# Altium schematic sheet LED.SchDoc
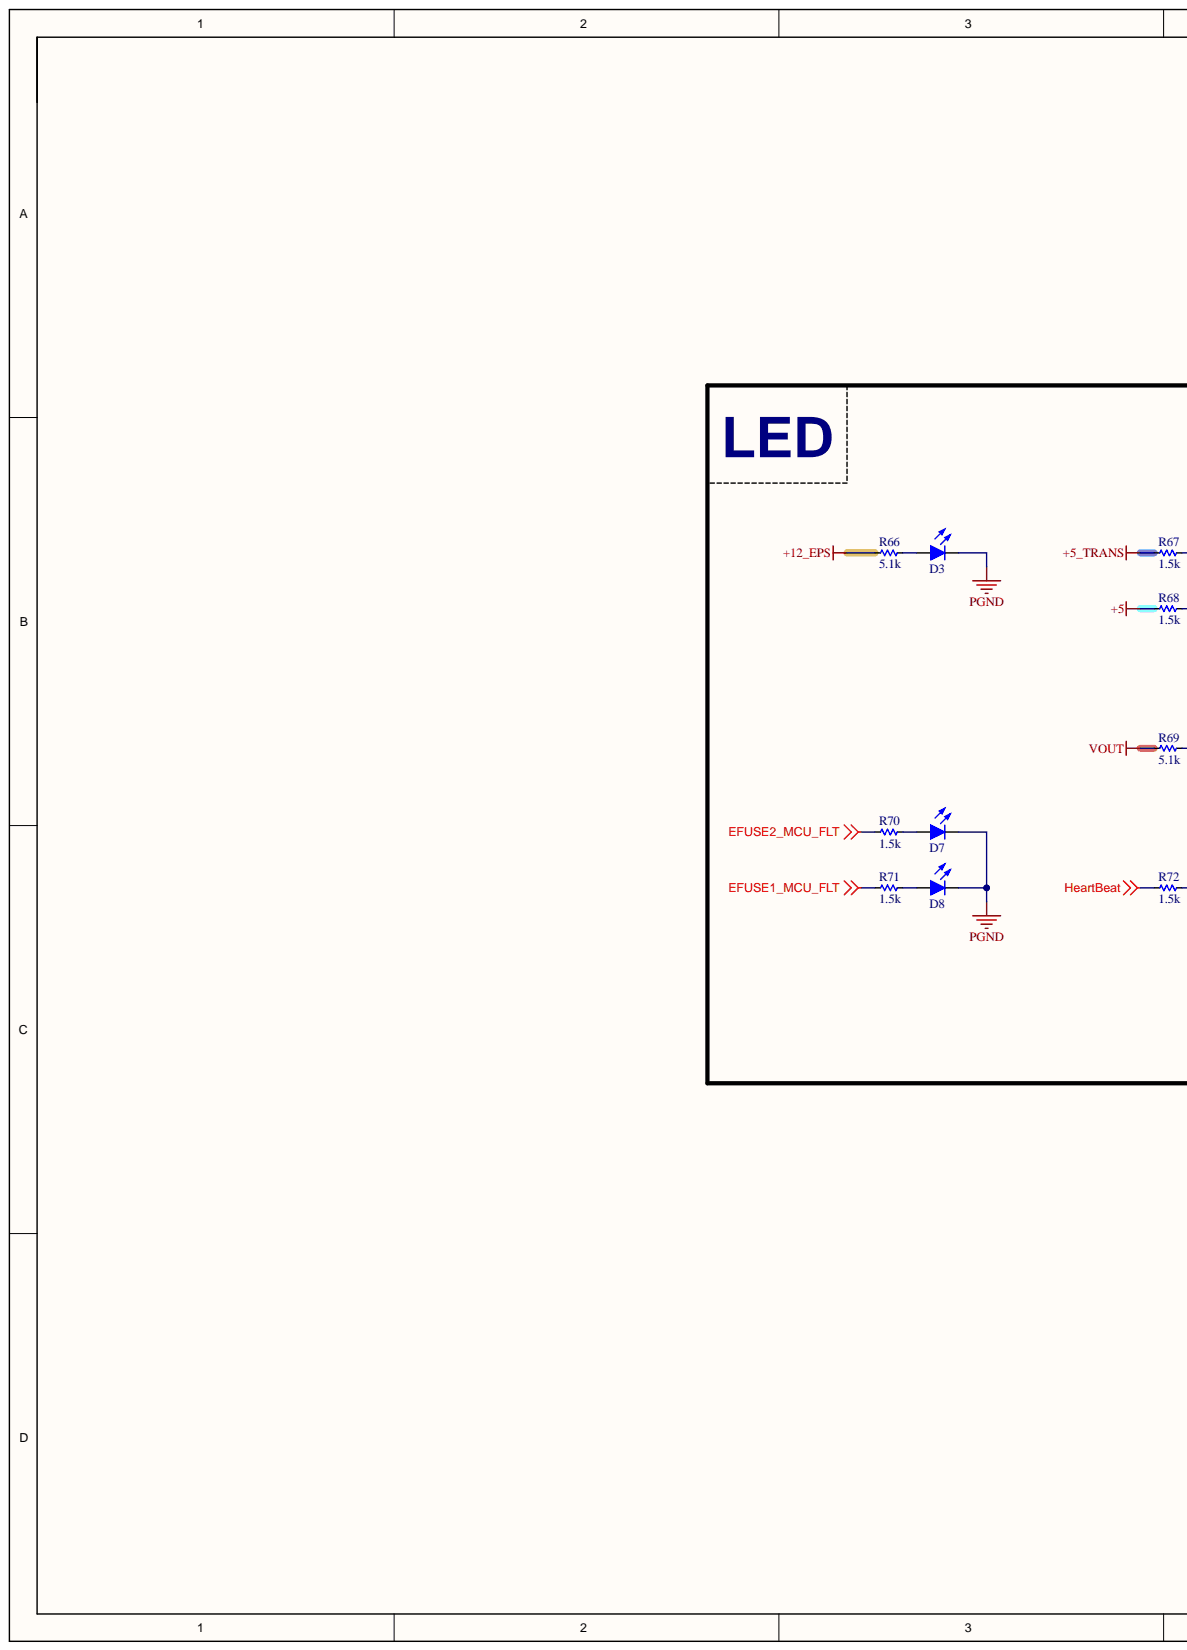
[diagram p0: LED.SchDoc, left]
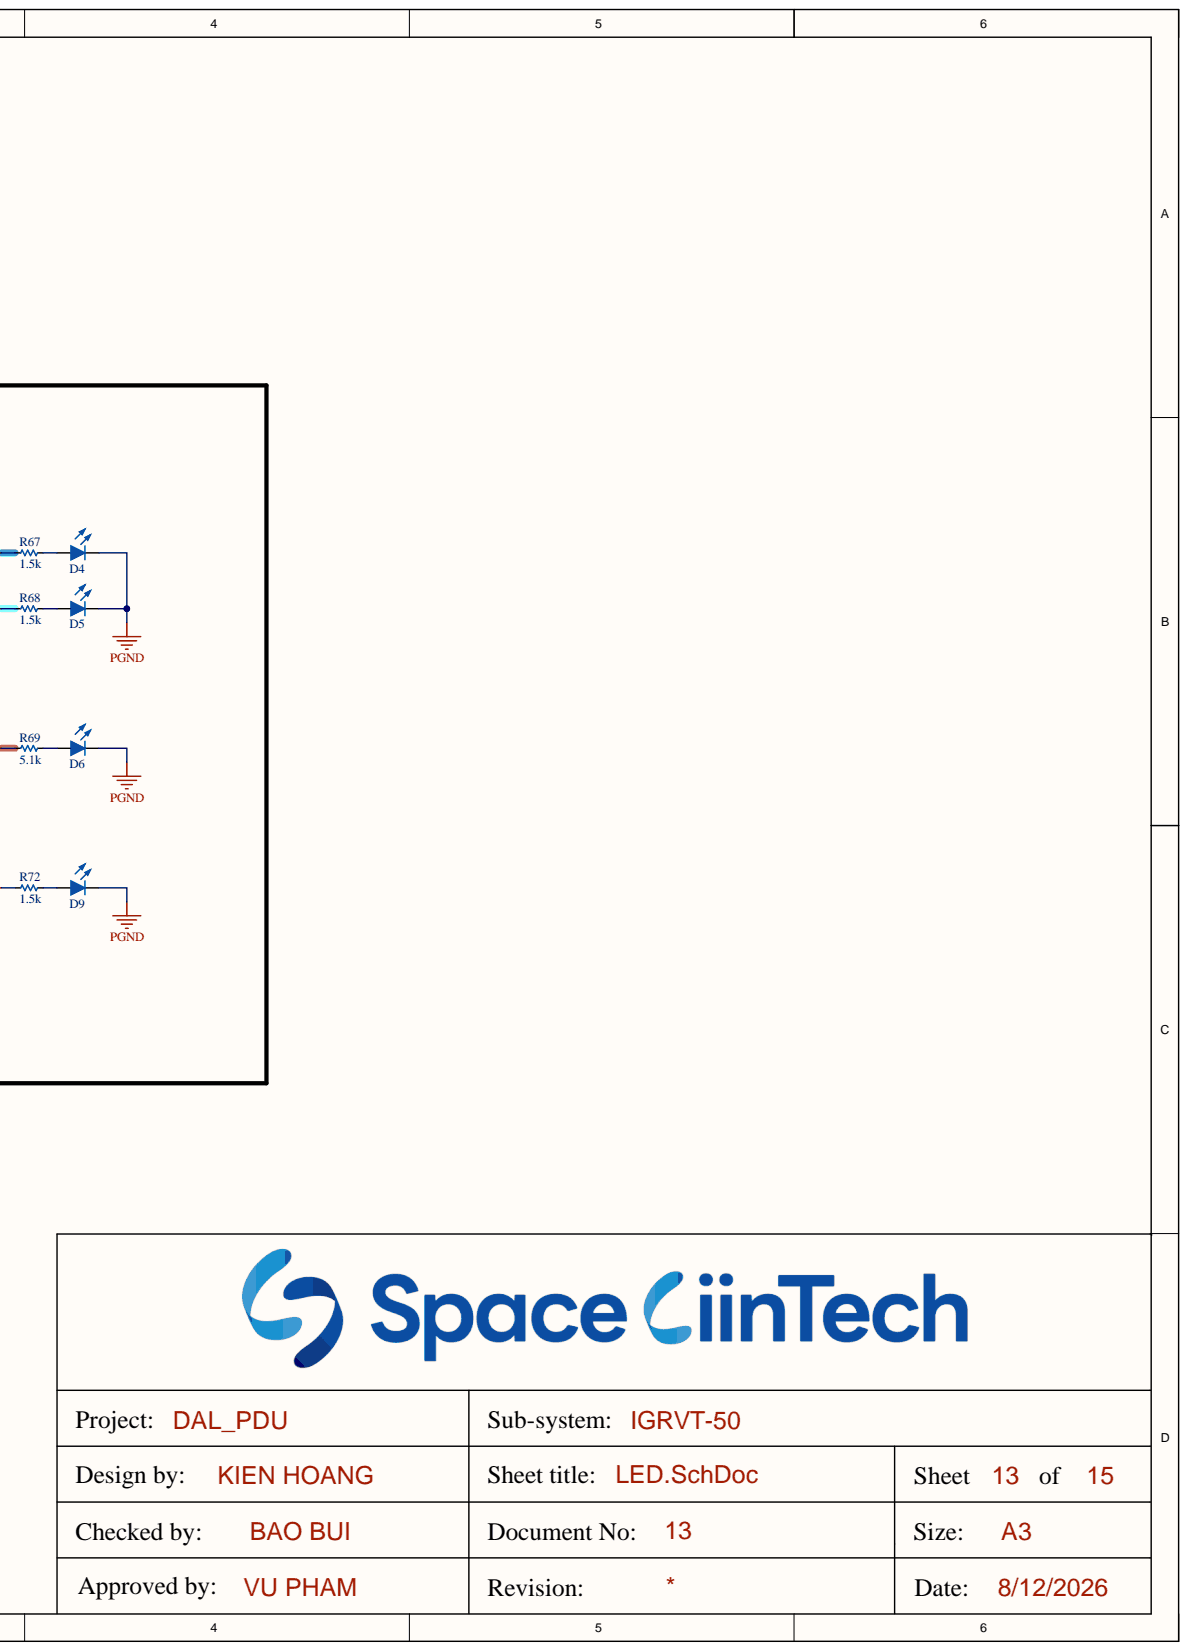
[diagram p1: LED.SchDoc, right]

## Parts
D3  Led  [Led 0603]  (value not drawn)
D4  Led  [Led 0603]  (value not drawn)
D5  Led  [Led 0603]  (value not drawn)
D6  Led  [Led 0603]  (value not drawn)
D7  Led  [Led 0603]  (value not drawn)
D8  Led  [Led 0603]  (value not drawn)
D9  Led  [Led 0603]  (value not drawn)
R66  5.1k  [RES_C_1608X60]
R67  1.5k  [RES_C_1608X60]
R68  1.5k  [RES_C_1608X60]
R69  5.1k  [RES_C_1608X60]
R70  1.5k  [RES_C_1608X60]
R71  1.5k  [RES_C_1608X60]
R72  1.5k  [RES_C_1608X60]

## Nets
+5: R68.2
+5_TRANS: R67.2
+12_EPS: R66.2
EFUSE1_MCU_FLT: R71.2
EFUSE2_MCU_FLT: R70.2
HeartBeat: R72.2
PGND: D3.2 D4.2 D5.2 D6.2 D7.2 D8.2 D9.2
VOUT: R69.2
NetD3_1: D3.1 R66.1
NetD4_1: D4.1 R67.1
NetD5_1: D5.1 R68.1
NetD6_1: D6.1 R69.1
NetD7_1: D7.1 R70.1
NetD8_1: D8.1 R71.1
NetD9_1: D9.1 R72.1

## Library data of the parts
D3-D9 = Led: Typical LED; Component Kind: Standard; Library Name: KIENASIY123_LIB.IntLib
R66-R72 = _RES_B_2_2: RES; Component Kind: Standard; Library Name: LABLIB.SchLib
